# Altium schematic sheet 4-20mA输出.SchDoc(4-20mA输出)
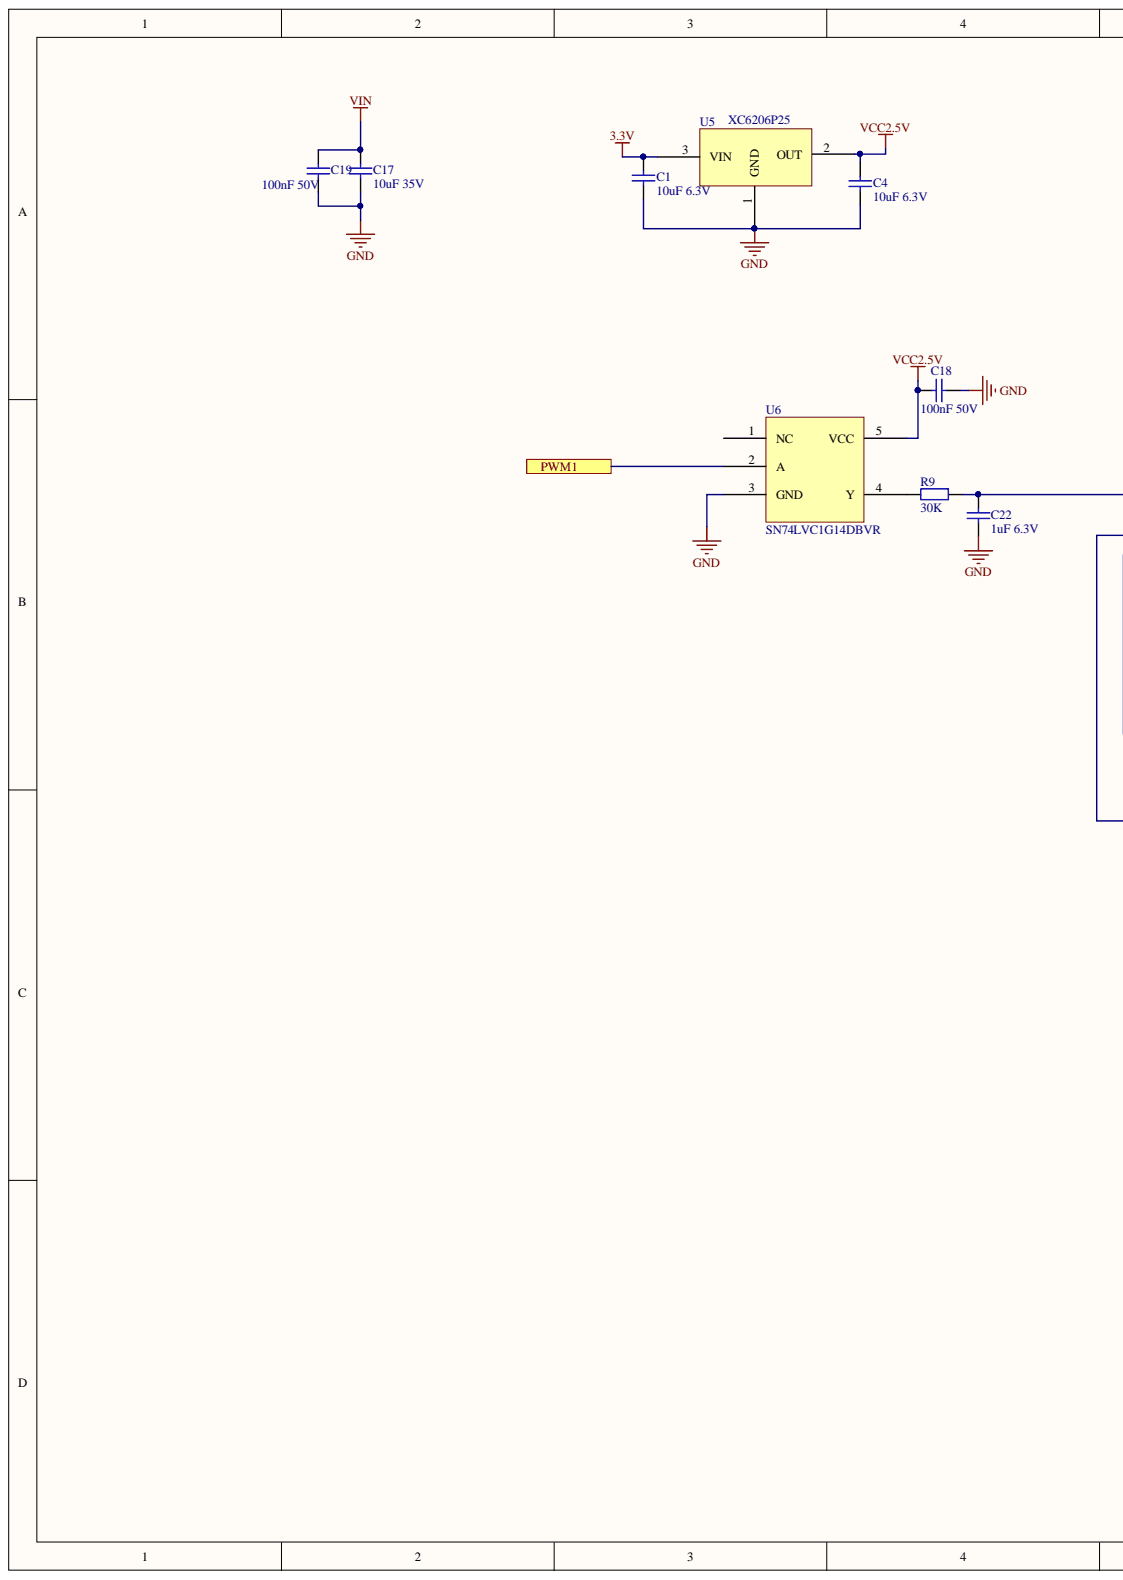
[diagram p0: 4-20mA输出.SchDoc, left]
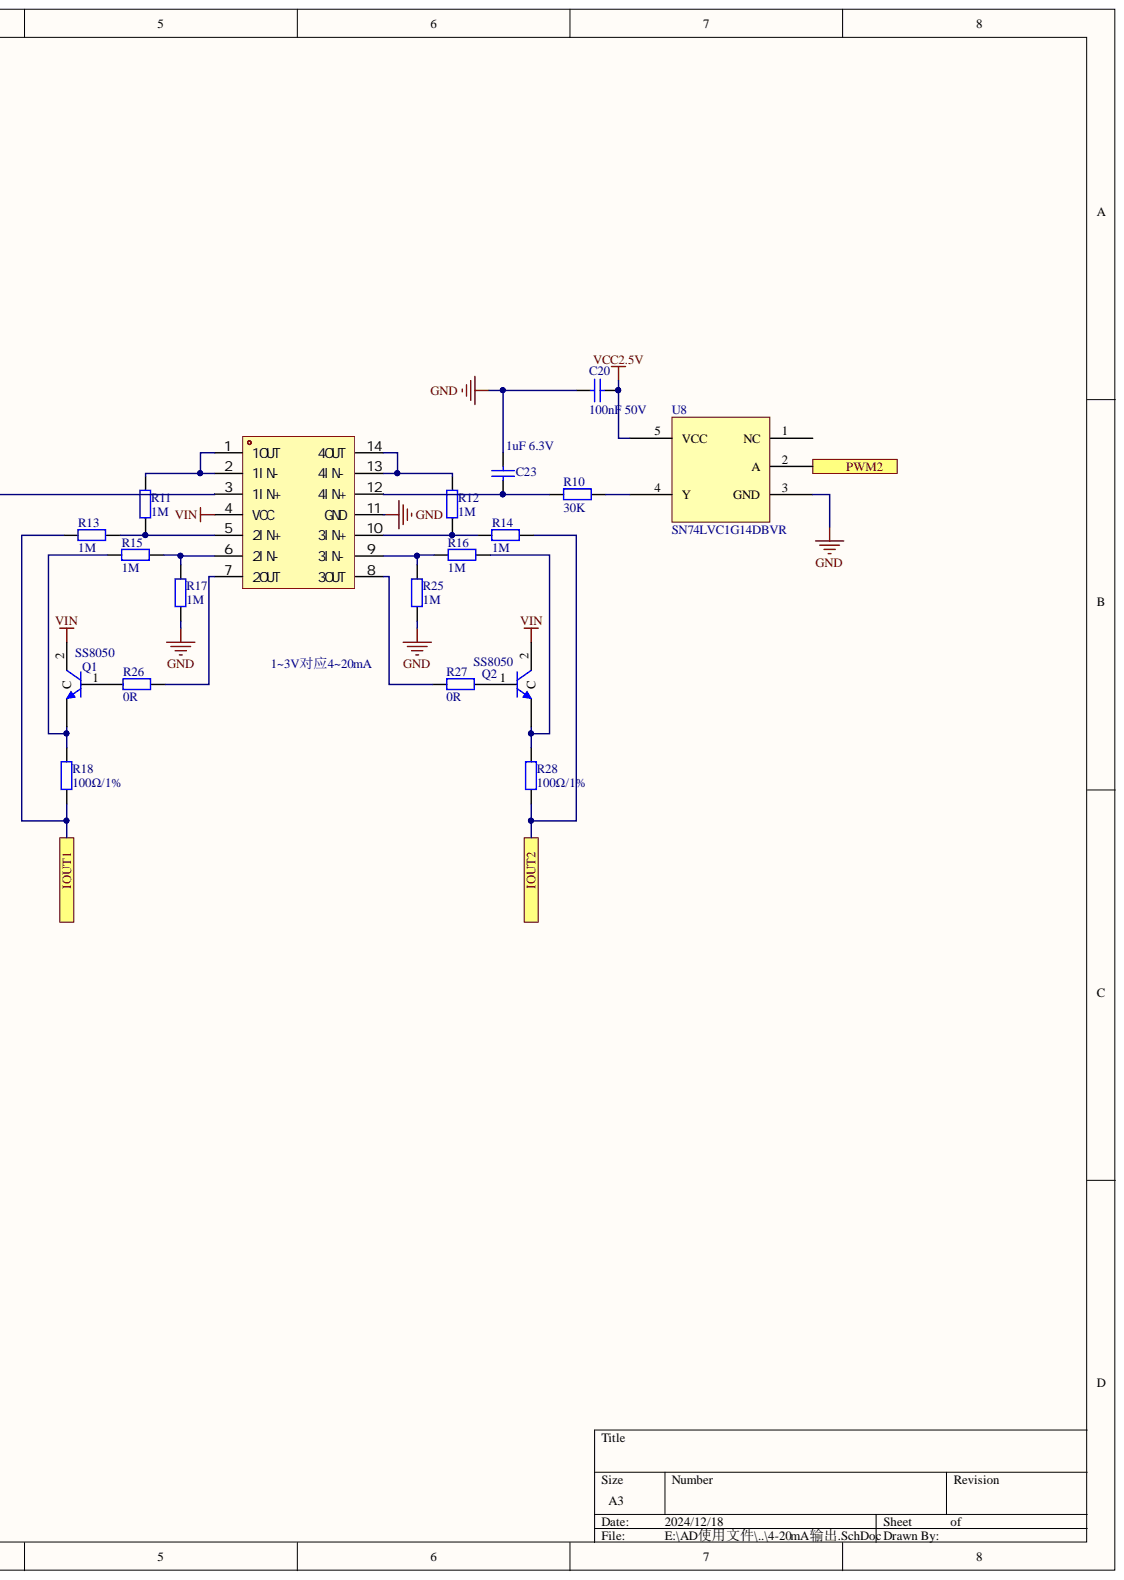
[diagram p1: 4-20mA输出.SchDoc, right]

## Parts
C1  10uF 6.3V  [0603_C]
C4  10uF 6.3V  [0603_C]
C17  10uF 35V  [0805_C]
C18  100nF 50V  [0603_C]
C19  100nF 50V  [0603_C]
C20  100nF 50V  [0603_C]
C22  1uF 6.3V  [0603_C]
C23  1uF 6.3V  [0603_C]
Q1  SS8050  [SOT-89(SOT-89-3)]
Q2  SS8050  [SOT-89(SOT-89-3)]
R9  30K  [0603_R]
R10  30K  [0603_R]
R11  1M  [0603_R]
R12  1M  [0603_R]
R13  1M  [0603_R]
R14  1M  [0603_R]
R15  1M  [0603_R]
R16  1M  [0603_R]
R17  1M  [0603_R]
R18  100Ω/1%  [0805_R]
R25  1M  [0603_R]
R26  0R  [0603_R]
R27  0R  [0603_R]
R28  100Ω/1%  [0805_R]
U5  XC6206P25  [SOT-23(SOT-23-3)]
U6  SN74LVC1G14DBVR  [SOT23-5]
U8  SN74LVC1G14DBVR  [SOT23-5]
U9  LM324DR  [SOIC-14_150mil]  (value not drawn)

## Ports
IOUT1, IOUT2, PWM1, PWM2

## Nets
3.3V: C1.1 U5.3
GND: C1.2 C4.2 C17.2 C18.1 C19.1 C20.1 C22.2 C23.2 R17.2 R25.2 U5.1 U6.3(GND) U8.3(GND) U9.11(GND)
VCC2.5V: C4.1 C18.2 C20.2 U5.2 U6.5(VCC) U8.5(VCC)
VIN: C17.1 C19.2 Q1.2 Q2.2 U9.4(VCC)
IOUT1: R13.1 R18.2 ; port IOUT1
IOUT2: R14.1 R28.2 ; port IOUT2
PWM1: U6.2(A) ; port PWM1
PWM2: U8.2(A) ; port PWM2
NetC22_1: C22.1 R9.2 U9.3(1IN+)
NetC23_1: C23.1 R10.1 U9.12(4IN+)
NetQ1_1: Q1.1 R26.1
NetQ1_3: Q1.3 R15.1 R18.1
NetQ2_1: Q2.1 R27.1
NetQ2_3: Q2.3 R16.1 R28.1
NetR9_1: R9.1 U6.4(Y)
NetR10_2: R10.2 U8.4(Y)
NetR11_1: R11.1 U9.1(1OUT) U9.2(1IN-)
NetR11_2: R11.2 R13.2 U9.5(2IN+)
NetR12_1: R12.1 U9.13(4IN-) U9.14(4OUT)
NetR12_2: R12.2 R14.2 U9.10(3IN+)
NetR15_2: R15.2 R17.1 U9.6(2IN-)
NetR16_2: R16.2 R25.1 U9.9(3IN-)
NetR26_2: R26.2 U9.7(2OUT)
NetR27_2: R27.2 U9.8(3OUT)

Not connected: U6 1(NC); U8 1(NC)

## Library data of the parts
C1 C4 C17 C19 C22 C23 = C(0805): 贴片电容; Component Kind: Standard; Library Name: SAM.SchLib
C18 C20 = 0402 100nF (104) 10% 16V: 贴片电容; SuppliersPartNumber: C1525; Supplier: LC; Package: 0402; Library Name: Cap.SchLib; ComponentLink1URL: http://www.szlcsc.com/product/details_1877.html; ComponentLink1Description: 供应商链接; Component Kind: Standard
Q1 Q2 = NPN: NPN Bipolar Transistor; Simulation: NPN; Published: 8-Jun-2000; PackageReference: TO-226-AA; PackageDescription: TO, Flat Index; 3 In-Line, Axial Leads; Body Dia. 4.8mm; Leads 0.55 x 0.51 mm (max); Library Name: 4G模块.SCHLIB; LatestRevisionNote: Re-released for DXP Platform.; LatestRevisionDate: 17-Jul-2002; Component Kind: Standard; Code_JEDEC: TO-226-AA; Publisher: Altium Limited
R9-R17 R25 = 0402 300Ω (3000) 1%: 贴片电阻; SuppliersPartNumber: C25102; Supplier: LC; Package: 0402; Library Name: RES.SchLib; ComponentLink1URL: http://www.szlcsc.com/product/details_25845.html; ComponentLink1Description: 供应商链接; Component Kind: Standard
R18 R26-R28 = Res2: 贴片电阻; Simulation: RESISTOR; Published: 8-Jun-2000; PackageReference: AXIAL-0.4; PackageDescription: Axial Device, Thru-Hole; 2 Leads; 0.4 in Pin Spacing; Library Name: Miscellaneous Devices.IntLib; LatestRevisionNote: Re-released for DXP Platform.; LatestRevisionDate: 17-Jul-2002; Component Kind: Standard; Publisher: Altium Limited
U9 = LM224DT: Manufacturer: TI(德州仪器); Slew Rate(SR): 0.5V/us; Quiescent Current: 1.4mA; Output Current: 30mA; Number of Circuits: 四路; Gain Bandwidth Product(GBP): 1.2MHz; Current - Input Bias(Ib): 20nA; Convert to PCB: yes; Symbol: LM324DR; Supplier Part: C7943; Supplier Footprint: SOIC-14; Supplier: LCSC; Operating Temperature: 0℃~+70℃; Manufacturer Part: LM324DR; LCSC Part Name: LM324DR; JLCPCB Part Class: Extended Part; Device: LM324DR; Datasheet: http://www.ti.com/cn/lit/gpn/lm324; Component Kind: Standard; Add into BOM: yes; Library Name: LDGlib.SchLib
U5 = XC6206P25: Component Kind: Standard; Library Name: LDGlib.SchLib
U6 U8 = SN74LVC1G14DBVR: Component Kind: Standard; Library Name: LDGlib.SchLib
